# Altium schematic sheet CM001_V4_7.SchDoc(CM001_V4_7)
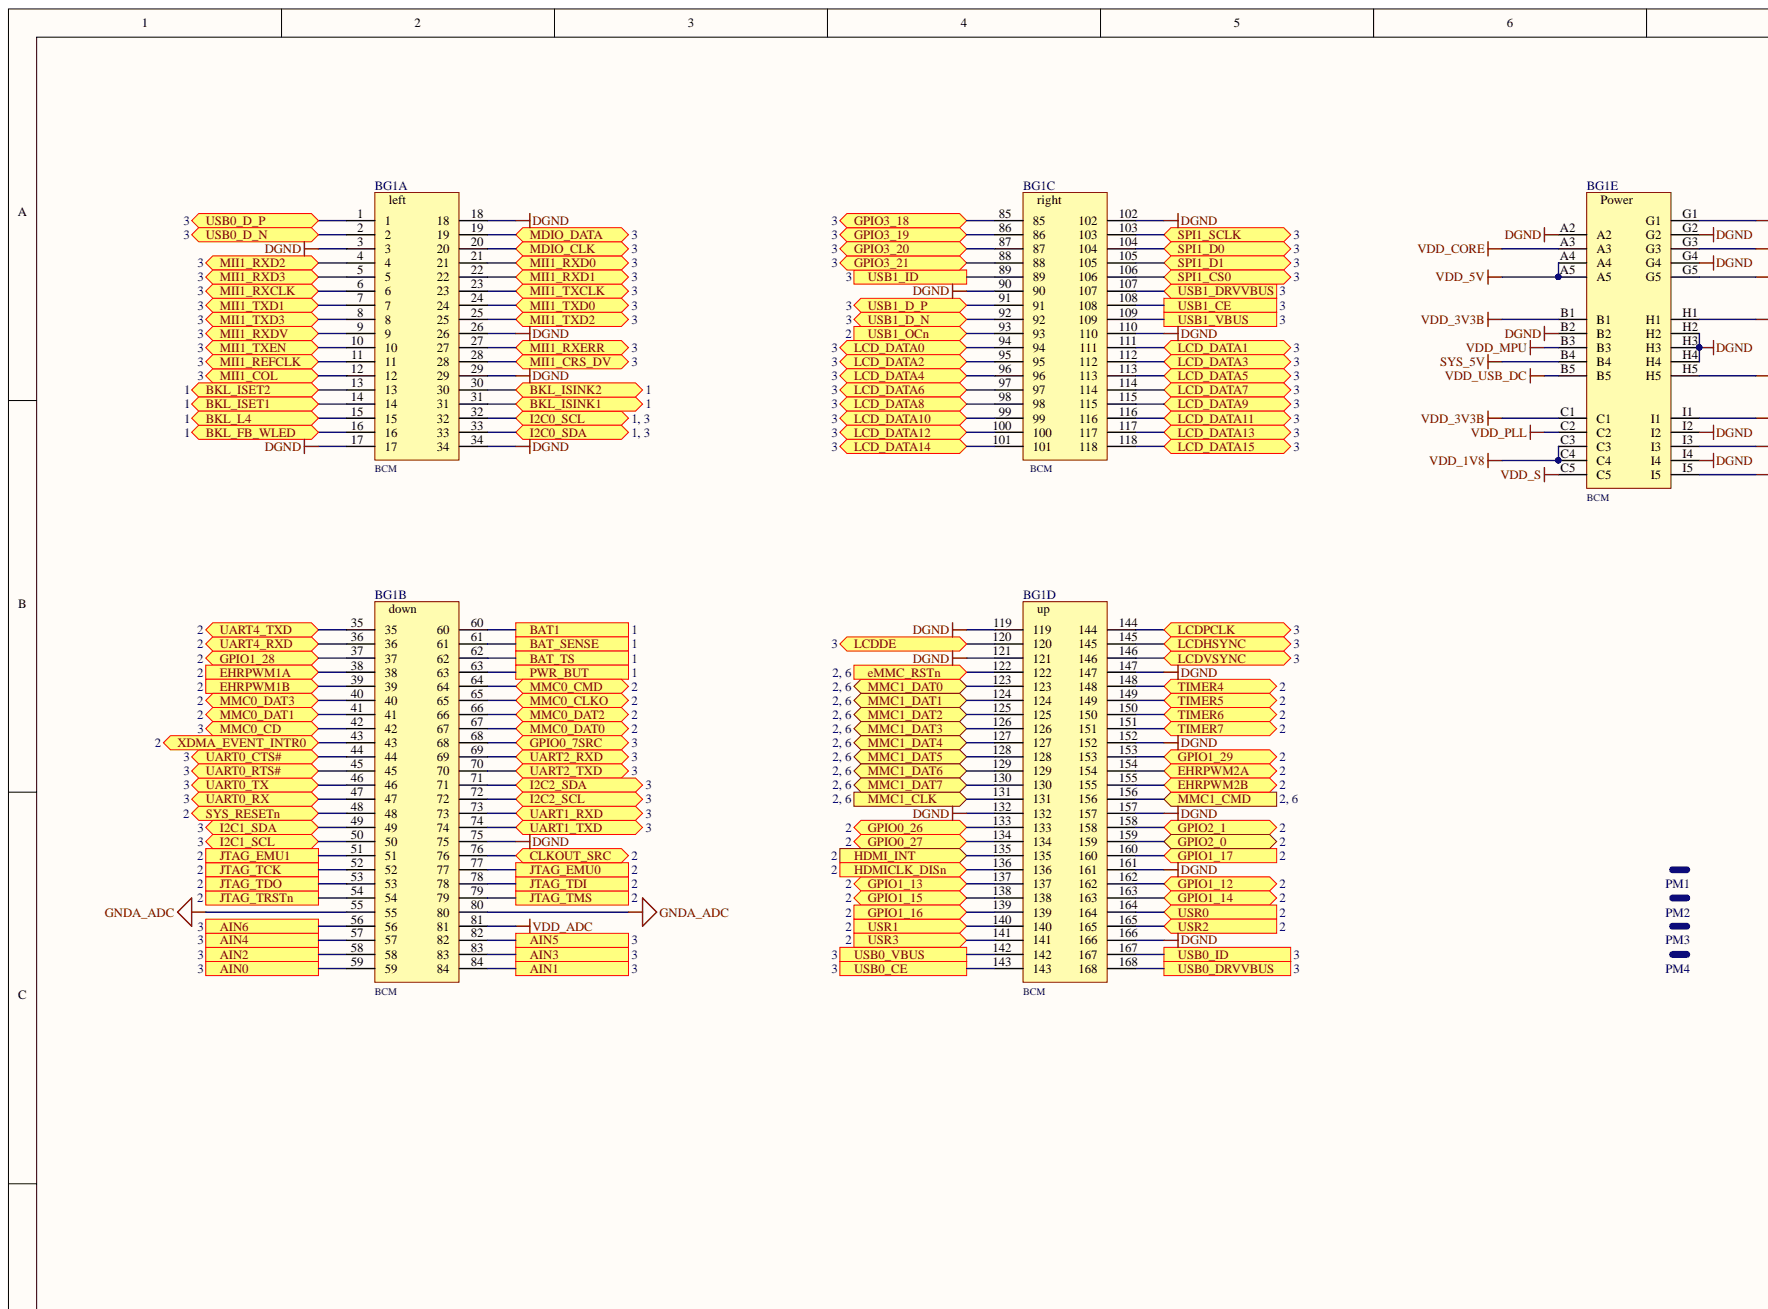
[diagram p0: CM001_V4_7.SchDoc]

## Parts
BG1  BCM  [BCM]  (no BOM)  (parts A,B,C,D,E)
PM1  PMR  [PASSER_RUND]  (value not drawn)
PM2  PMR  [PASSER_RUND]  (value not drawn)
PM3  PMR  [PASSER_RUND]  (value not drawn)
PM4  PMR  [PASSER_RUND]  (value not drawn)

## Ports
AIN0, AIN1, AIN2, AIN3, AIN4, AIN5, AIN6, BAT1, BAT_SENSE, BAT_TS, BKL_FB_WLED, BKL_ISET1, BKL_ISET2, BKL_ISINK1, BKL_ISINK2, BKL_L4, CLKOUT_SRC, EHRPWM1A, EHRPWM1B, EHRPWM2A, EHRPWM2B, eMMC_RSTn, GPIO0_7SRC, GPIO0_26, GPIO0_27, GPIO1_12, GPIO1_13, GPIO1_14, GPIO1_15, GPIO1_16, GPIO1_17, GPIO1_28, GPIO1_29, GPIO2_0, GPIO2_1, GPIO3_18, GPIO3_19, GPIO3_20, GPIO3_21, HDMI_INT, HDMICLK_DISn, I2C0_SCL, I2C0_SDA, I2C1_SCL, I2C1_SDA, I2C2_SCL, I2C2_SDA, JTAG_EMU0, JTAG_EMU1, JTAG_TCK, JTAG_TDI, JTAG_TDO, JTAG_TMS, JTAG_TRSTn, LCD_DATA0, LCD_DATA1, LCD_DATA2, LCD_DATA3, LCD_DATA4, LCD_DATA5, LCD_DATA6, LCD_DATA7, LCD_DATA8, LCD_DATA9, LCD_DATA10, LCD_DATA11, LCD_DATA12, LCD_DATA13, LCD_DATA14, LCD_DATA15, LCDDE, LCDHSYNC, LCDPCLK, LCDVSYNC, MDIO_CLK, MDIO_DATA, MII1_COL, MII1_CRS_DV, MII1_REFCLK, MII1_RXCLK, MII1_RXD0, MII1_RXD1, MII1_RXD2, MII1_RXD3, MII1_RXDV, MII1_RXERR, MII1_TXCLK, MII1_TXD0, MII1_TXD1, MII1_TXD2, MII1_TXD3, MII1_TXEN, MMC0_CD, MMC0_CLKO, MMC0_CMD, MMC0_DAT0, MMC0_DAT1, MMC0_DAT2, MMC0_DAT3, MMC1_CLK, MMC1_CMD, MMC1_DAT0, MMC1_DAT1, MMC1_DAT2, MMC1_DAT3, MMC1_DAT4, MMC1_DAT5, MMC1_DAT6, MMC1_DAT7, PWR_BUT, SPI1_CS0, SPI1_D0, SPI1_D1, SPI1_SCLK, SYS_RESETn, TIMER4, TIMER5, TIMER6, TIMER7, UART0_CTS#, UART0_RTS#, UART0_RX, UART0_TX, UART1_RXD, UART1_TXD, UART2_RXD, UART2_TXD, UART4_RXD, UART4_TXD, USB0_CE, USB0_D_N, USB0_D_P, USB0_DRVVBUS, USB0_ID, USB0_VBUS, USB1_CE, USB1_D_N, USB1_D_P, USB1_DRVVBUS, USB1_ID, USB1_OCn, USB1_VBUS, USR0, USR1, USR2, USR3, XDMA_EVENT_INTR0

## Nets
DGND: BG1.3 BG1.17 BG1.18 BG1.26 BG1.29 BG1.34 BG1.75 BG1.90 BG1.102 BG1.110 BG1.119 BG1.121 BG1.132 BG1.147 BG1.152 BG1.157 BG1.161 BG1.166 BG1.A2 BG1.B2 BG1.G2 BG1.G4 BG1.H2 BG1.H3 BG1.H4 BG1.I2 BG1.I4
GNDA_ADC: BG1.55 BG1.80 BG1.G5
SYS_5V: BG1.B4
VDD_1V8: BG1.C3 BG1.C4
VDD_3V3A: BG1.G1
VDD_3V3B: BG1.B1 BG1.C1
VDD_5V: BG1.A4 BG1.A5
VDD_ADC: BG1.81 BG1.H5
VDD_CORE: BG1.A3 BG1.H1 BG1.I1
VDD_MPU: BG1.B3
VDD_PLL: BG1.C2
VDD_S: BG1.C5 BG1.G3
VDD_USB_DC: BG1.B5
VDDS_DDR: BG1.I3 BG1.I5
AIN0: BG1.59 ; port AIN0
AIN1: BG1.84 ; port AIN1
AIN2: BG1.58 ; port AIN2
AIN3: BG1.83 ; port AIN3
AIN4: BG1.57 ; port AIN4
AIN5: BG1.82 ; port AIN5
AIN6: BG1.56 ; port AIN6
BAT1: BG1.60 ; port BAT1
BAT_SENSE: BG1.61 ; port BAT_SENSE
BAT_TS: BG1.62 ; port BAT_TS
BKL_FB_WLED: BG1.16 ; port BKL_FB_WLED
BKL_ISET1: BG1.14 ; port BKL_ISET1
BKL_ISET2: BG1.13 ; port BKL_ISET2
BKL_ISINK1: BG1.31 ; port BKL_ISINK1
BKL_ISINK2: BG1.30 ; port BKL_ISINK2
BKL_L4: BG1.15 ; port BKL_L4
CLKOUT_SRC: BG1.76 ; port CLKOUT_SRC
EHRPWM1A: BG1.38 ; port EHRPWM1A
EHRPWM1B: BG1.39 ; port EHRPWM1B
EHRPWM2A: BG1.154 ; port EHRPWM2A
EHRPWM2B: BG1.155 ; port EHRPWM2B
eMMC_RSTn: BG1.122 ; port eMMC_RSTn
GPIO0_7SRC: BG1.68 ; port GPIO0_7SRC
GPIO0_26: BG1.133 ; port GPIO0_26
GPIO0_27: BG1.134 ; port GPIO0_27
GPIO1_12: BG1.162 ; port GPIO1_12
GPIO1_13: BG1.137(2,_6) ; port GPIO1_13
GPIO1_14: BG1.163 ; port GPIO1_14
GPIO1_15: BG1.138(2,_6) ; port GPIO1_15
GPIO1_16: BG1.139(2,_6) ; port GPIO1_16
GPIO1_17: BG1.160 ; port GPIO1_17
GPIO1_28: BG1.37 ; port GPIO1_28
GPIO1_29: BG1.153 ; port GPIO1_29
GPIO2_0: BG1.159 ; port GPIO2_0
GPIO2_1: BG1.158 ; port GPIO2_1
GPIO3_18: BG1.85 ; port GPIO3_18
GPIO3_19: BG1.86 ; port GPIO3_19
GPIO3_20: BG1.87 ; port GPIO3_20
GPIO3_21: BG1.88 ; port GPIO3_21
HDMI_INT: BG1.135(2,_6) ; port HDMI_INT
HDMICLK_DISn: BG1.136 ; port HDMICLK_DISn
I2C0_SCL: BG1.32 ; port I2C0_SCL
I2C0_SDA: BG1.33 ; port I2C0_SDA
I2C1_SCL: BG1.50 ; port I2C1_SCL
I2C1_SDA: BG1.49 ; port I2C1_SDA
I2C2_SCL: BG1.72 ; port I2C2_SCL
I2C2_SDA: BG1.71 ; port I2C2_SDA
JTAG_EMU0: BG1.77 ; port JTAG_EMU0
JTAG_EMU1: BG1.51 ; port JTAG_EMU1
JTAG_TCK: BG1.52 ; port JTAG_TCK
JTAG_TDI: BG1.78 ; port JTAG_TDI
JTAG_TDO: BG1.53 ; port JTAG_TDO
JTAG_TMS: BG1.79 ; port JTAG_TMS
JTAG_TRSTn: BG1.54 ; port JTAG_TRSTn
LCD_DATA0: BG1.94 ; port LCD_DATA0
LCD_DATA1: BG1.111 ; port LCD_DATA1
LCD_DATA2: BG1.95 ; port LCD_DATA2
LCD_DATA3: BG1.112 ; port LCD_DATA3
LCD_DATA4: BG1.96 ; port LCD_DATA4
LCD_DATA5: BG1.113 ; port LCD_DATA5
LCD_DATA6: BG1.97 ; port LCD_DATA6
LCD_DATA7: BG1.114 ; port LCD_DATA7
LCD_DATA8: BG1.98 ; port LCD_DATA8
LCD_DATA9: BG1.115 ; port LCD_DATA9
LCD_DATA10: BG1.99 ; port LCD_DATA10
LCD_DATA11: BG1.116 ; port LCD_DATA11
LCD_DATA12: BG1.100 ; port LCD_DATA12
LCD_DATA13: BG1.117 ; port LCD_DATA13
LCD_DATA14: BG1.101 ; port LCD_DATA14
LCD_DATA15: BG1.118 ; port LCD_DATA15
LCDDE: BG1.120 ; port LCDDE
LCDHSYNC: BG1.145 ; port LCDHSYNC
LCDPCLK: BG1.144 ; port LCDPCLK
LCDVSYNC: BG1.146 ; port LCDVSYNC
MDIO_CLK: BG1.20 ; port MDIO_CLK
MDIO_DATA: BG1.19 ; port MDIO_DATA
MII1_COL: BG1.12 ; port MII1_COL
MII1_CRS_DV: BG1.28 ; port MII1_CRS_DV
MII1_REFCLK: BG1.11 ; port MII1_REFCLK
MII1_RXCLK: BG1.6 ; port MII1_RXCLK
MII1_RXD0: BG1.21 ; port MII1_RXD0
MII1_RXD1: BG1.22 ; port MII1_RXD1
MII1_RXD2: BG1.4 ; port MII1_RXD2
MII1_RXD3: BG1.5 ; port MII1_RXD3
MII1_RXDV: BG1.9 ; port MII1_RXDV
MII1_RXERR: BG1.27 ; port MII1_RXERR
MII1_TXCLK: BG1.23 ; port MII1_TXCLK
MII1_TXD0: BG1.24 ; port MII1_TXD0
MII1_TXD1: BG1.7 ; port MII1_TXD1
MII1_TXD2: BG1.25 ; port MII1_TXD2
MII1_TXD3: BG1.8 ; port MII1_TXD3
MII1_TXEN: BG1.10 ; port MII1_TXEN
MMC0_CD: BG1.42 ; port MMC0_CD
MMC0_CLKO: BG1.65 ; port MMC0_CLKO
MMC0_CMD: BG1.64 ; port MMC0_CMD
MMC0_DAT0: BG1.67 ; port MMC0_DAT0
MMC0_DAT1: BG1.41 ; port MMC0_DAT1
MMC0_DAT2: BG1.66 ; port MMC0_DAT2
MMC0_DAT3: BG1.40 ; port MMC0_DAT3
MMC1_CLK: BG1.131 ; port MMC1_CLK
MMC1_CMD: BG1.156 ; port MMC1_CMD
MMC1_DAT0: BG1.123 ; port MMC1_DAT0
MMC1_DAT1: BG1.124 ; port MMC1_DAT1
MMC1_DAT2: BG1.125 ; port MMC1_DAT2
MMC1_DAT3: BG1.126 ; port MMC1_DAT3
MMC1_DAT4: BG1.127 ; port MMC1_DAT4
MMC1_DAT5: BG1.128 ; port MMC1_DAT5
MMC1_DAT6: BG1.129 ; port MMC1_DAT6
MMC1_DAT7: BG1.130 ; port MMC1_DAT7
PWR_BUT: BG1.63 ; port PWR_BUT
SPI1_CS0: BG1.106 ; port SPI1_CS0
SPI1_D0: BG1.104 ; port SPI1_D0
SPI1_D1: BG1.105 ; port SPI1_D1
SPI1_SCLK: BG1.103 ; port SPI1_SCLK
SYS_RESETn: BG1.48 ; port SYS_RESETn
TIMER4: BG1.148 ; port TIMER4
TIMER5: BG1.149 ; port TIMER5
TIMER6: BG1.150 ; port TIMER6
TIMER7: BG1.151 ; port TIMER7
UART0_CTS#: BG1.44 ; port UART0_CTS#
UART0_RTS#: BG1.45 ; port UART0_RTS#
UART0_RX: BG1.47 ; port UART0_RX
UART0_TX: BG1.46 ; port UART0_TX
UART1_RXD: BG1.73 ; port UART1_RXD
UART1_TXD: BG1.74 ; port UART1_TXD
UART2_RXD: BG1.69 ; port UART2_RXD
UART2_TXD: BG1.70 ; port UART2_TXD
UART4_RXD: BG1.36 ; port UART4_RXD
UART4_TXD: BG1.35 ; port UART4_TXD
USB0_CE: BG1.143(2,_6) ; port USB0_CE
USB0_D_N: BG1.2 ; port USB0_D_N
USB0_D_P: BG1.1 ; port USB0_D_P
USB0_DRVVBUS: BG1.168 ; port USB0_DRVVBUS
USB0_ID: BG1.167 ; port USB0_ID
USB0_VBUS: BG1.142 ; port USB0_VBUS
USB1_CE: BG1.108 ; port USB1_CE
USB1_D_N: BG1.92 ; port USB1_D_N
USB1_D_P: BG1.91 ; port USB1_D_P
USB1_DRVVBUS: BG1.107 ; port USB1_DRVVBUS
USB1_ID: BG1.89 ; port USB1_ID
USB1_OCn: BG1.93 ; port USB1_OCn
USB1_VBUS: BG1.109 ; port USB1_VBUS
USR0: BG1.164 ; port USR0
USR1: BG1.140(2,_6) ; port USR1
USR2: BG1.165 ; port USR2
USR3: BG1.141 ; port USR3
XDMA_EVENT_INTR0: BG1.43 ; port XDMA_EVENT_INTR0

## Library data of the parts
BG1 = BCM: Beaglecore; Value: BCM; Library Name: BG_ies_Integrated_Library.IntLib
PM1-PM4 = A_PMR: Passer Markierung rund; Value: PMR; Revision: July-2002: Re-released for DXP Platform.; Published: 24-Mar-1999; Component Kind: Standard; Library Name: A_ies_Integrated_Library.IntLib
